# Altium schematic sheet Buck-Boost converter (B).SchDoc("Buck-Boost converter (B)")
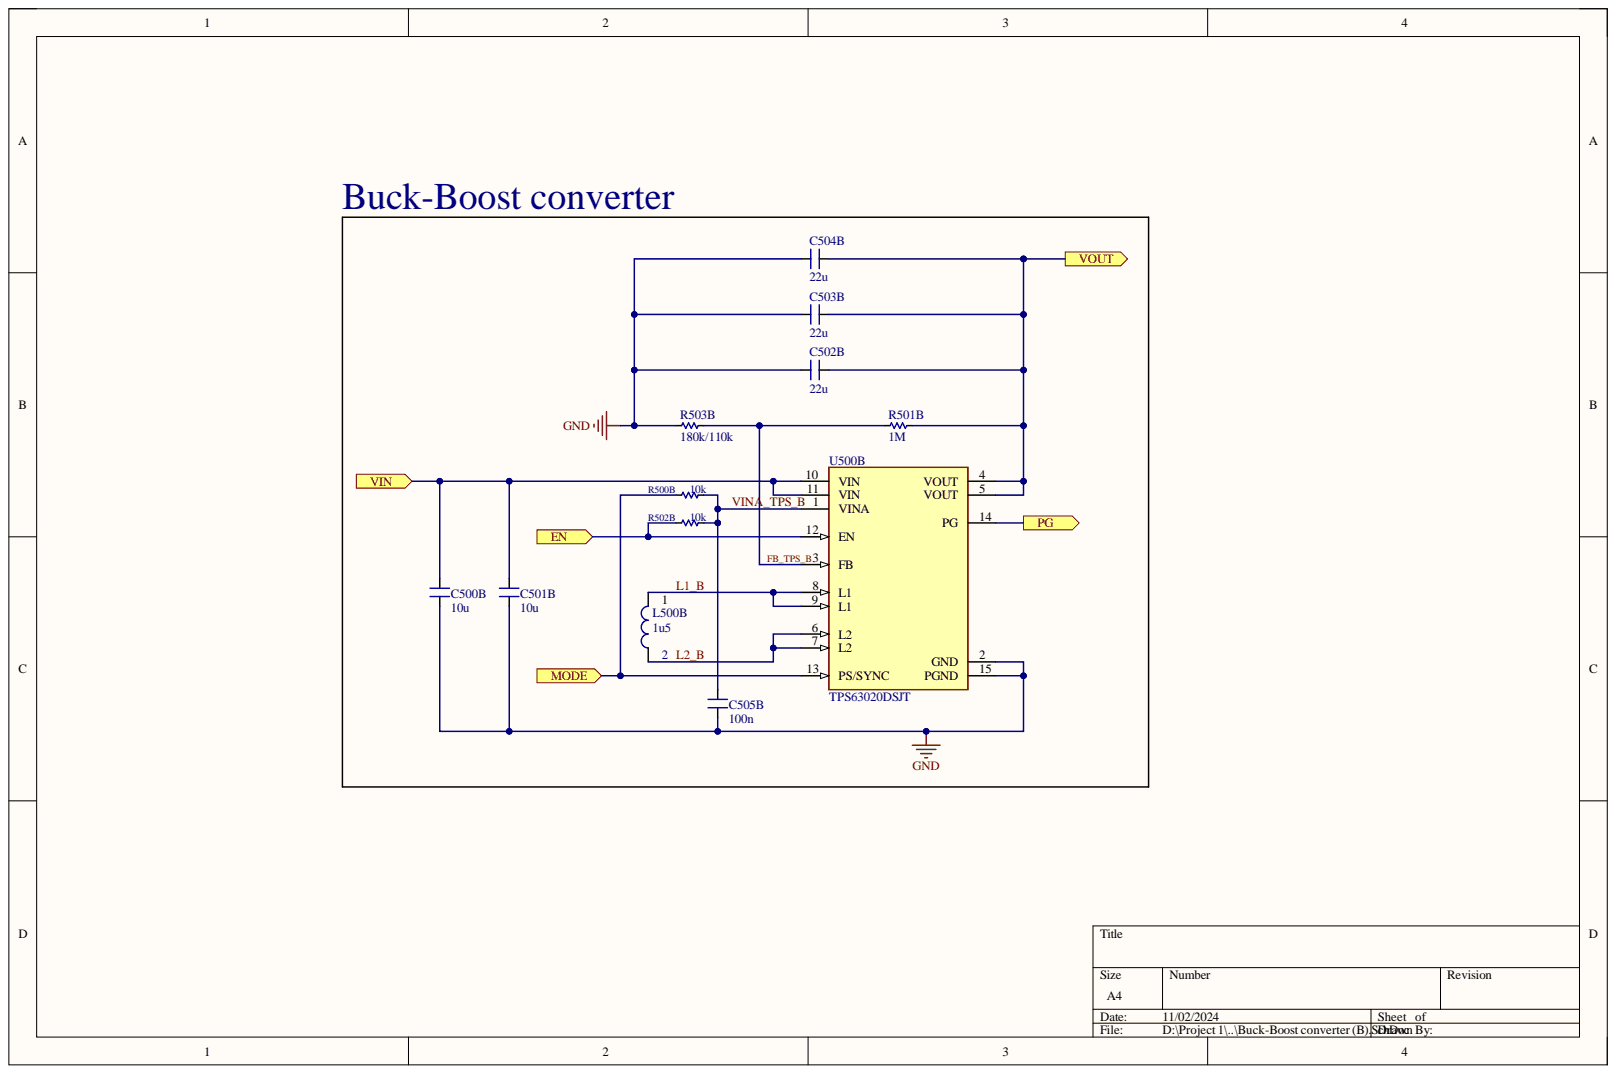
[diagram p0: Buck-Boost converter ]

## Parts
C500B  10u  [CN-SMD-0603]
C501B  10u  [CN-SMD-0603]
C502B  22u  [CN-SMD-0603]
C503B  22u  [CN-SMD-0603]
C504B  22u  [CN-SMD-0603]
C505B  100n  [CN-SMD-0603]
L500B  1u5  [L-SMD-0630]
R500B  10k  [RES-SMD-0603]
R501B  1M  [RES-SMD-0603]
R502B  10k  [RES-SMD-0603]
R503B  180k/110k  [RES-SMD-0603]
U500B  TPS63020DSJT  [FP-DSJ0014A-MFG]

## Ports
EN, MODE, PG, VIN, VOUT

## Nets
GND: C500B.1 C501B.1 C502B.2 C503B.2 C504B.2 C505B.1 R503B.2 U500B.2(GND) U500B.15(PGND)
EN: R502B.2 U500B.12(EN) ; port EN
FB_TPS_B: R501B.2 R503B.1 U500B.3(FB)
L1_B: L500B.2 U500B.8(L1) U500B.9(L1)
L2_B: L500B.1 U500B.6(L2) U500B.7(L2)
MODE: R500B.2 U500B.13(PS/SYNC) ; port MODE
PG: U500B.14(PG) ; port PG
VIN: C500B.2 C501B.2 U500B.10(VIN) U500B.11(VIN) ; port VIN
VINA_TPS_B: C505B.2 R500B.1 R502B.1 U500B.1(VINA)
VOUT: C502B.1 C503B.1 C504B.1 R501B.1 U500B.4(VOUT) U500B.5(VOUT) ; port VOUT

## Library data of the parts
C500B C501B C502B C503B C504B C505B = CN: Value: 33pF; Component Kind: Standard; Library Name: Library.SchLib
L500B = L-SMD-1: Manufacturer: Wurth Elektronik; partNo: 78477010; Inductance: 1.0 μH; Component Kind: Standard; ComponentLink1Description: Manufacturer; ComponentLink1URL: http://www.we-online.de; ComponentLink2Description: Datasheet; ComponentLink2URL: http://katalog.we-online.de/pbs/datasheet/78477010.pdf; Created Date: Nov.2015; Library Name: Library.SchLib; Created by: Baojun Chen
R500B R501B R502B R503B = Res-SMD: Resistor; Manufacturer: Generic Components; Class: Passive; Component Kind: Standard; Library Name: Library.SchLib; Published: 08/06/2000; Publisher: Altium Hobart Technology Centre
U500B = CMP-2000-07282-2: IC REG BCK BST ADJ 3.5A 14VSON; Manufacturer: Texas Instruments; Max Junction Temp: +125°C; Max Supply Voltage: 5.5V; Min Supply Voltage: 1.8V; Nominal Supply Current: 25uA; Number Of Outputs: 1; Output Current: 3A; Output Type: AdjustableProgrammable; Output Voltage: 1.2-5.5V; Standoff Height: 0mm; Switching Frequency: 2400kHz; Switching Topology: Buck-Boost; Ambient Temperature Range High: +85°C; Ambient Temperature Range Low: -40°C; Automotive: No; Category: IC; Component Kind: Standard; ComponentLink2Description: Datasheet; ComponentLink2URL: https://www.ti.com/lit/ds/symlink/tps63020.pdf; Device Class L1: Integrated Circuits (ICs); Device Class L2: Power Management ICs; Device Class L3: Voltage Regulators - Switching; Digikey Description: IC REG BCK BST ADJ 3.5A 14VSON; Height: 1mm; Lead Free: Yes; Library Name: Altium Content Vault; Manufacturer Part Number: TPS63020DSJT; Mouser Description: Voltage Regulators - Switching Regulators 93% Eff Buck-Boost Converter; Octopart: https://octopart.com/tps63020dsjt-texas+instruments-12976119; Package: DSJ0014A; RoHS: TRUE; Temperature: -40°C to +85°C; Temperature Range High: +85°C; Temperature Range Low: -40°C
